# Altium schematic sheet Measurement_board.SchDoc(Measurement_board)
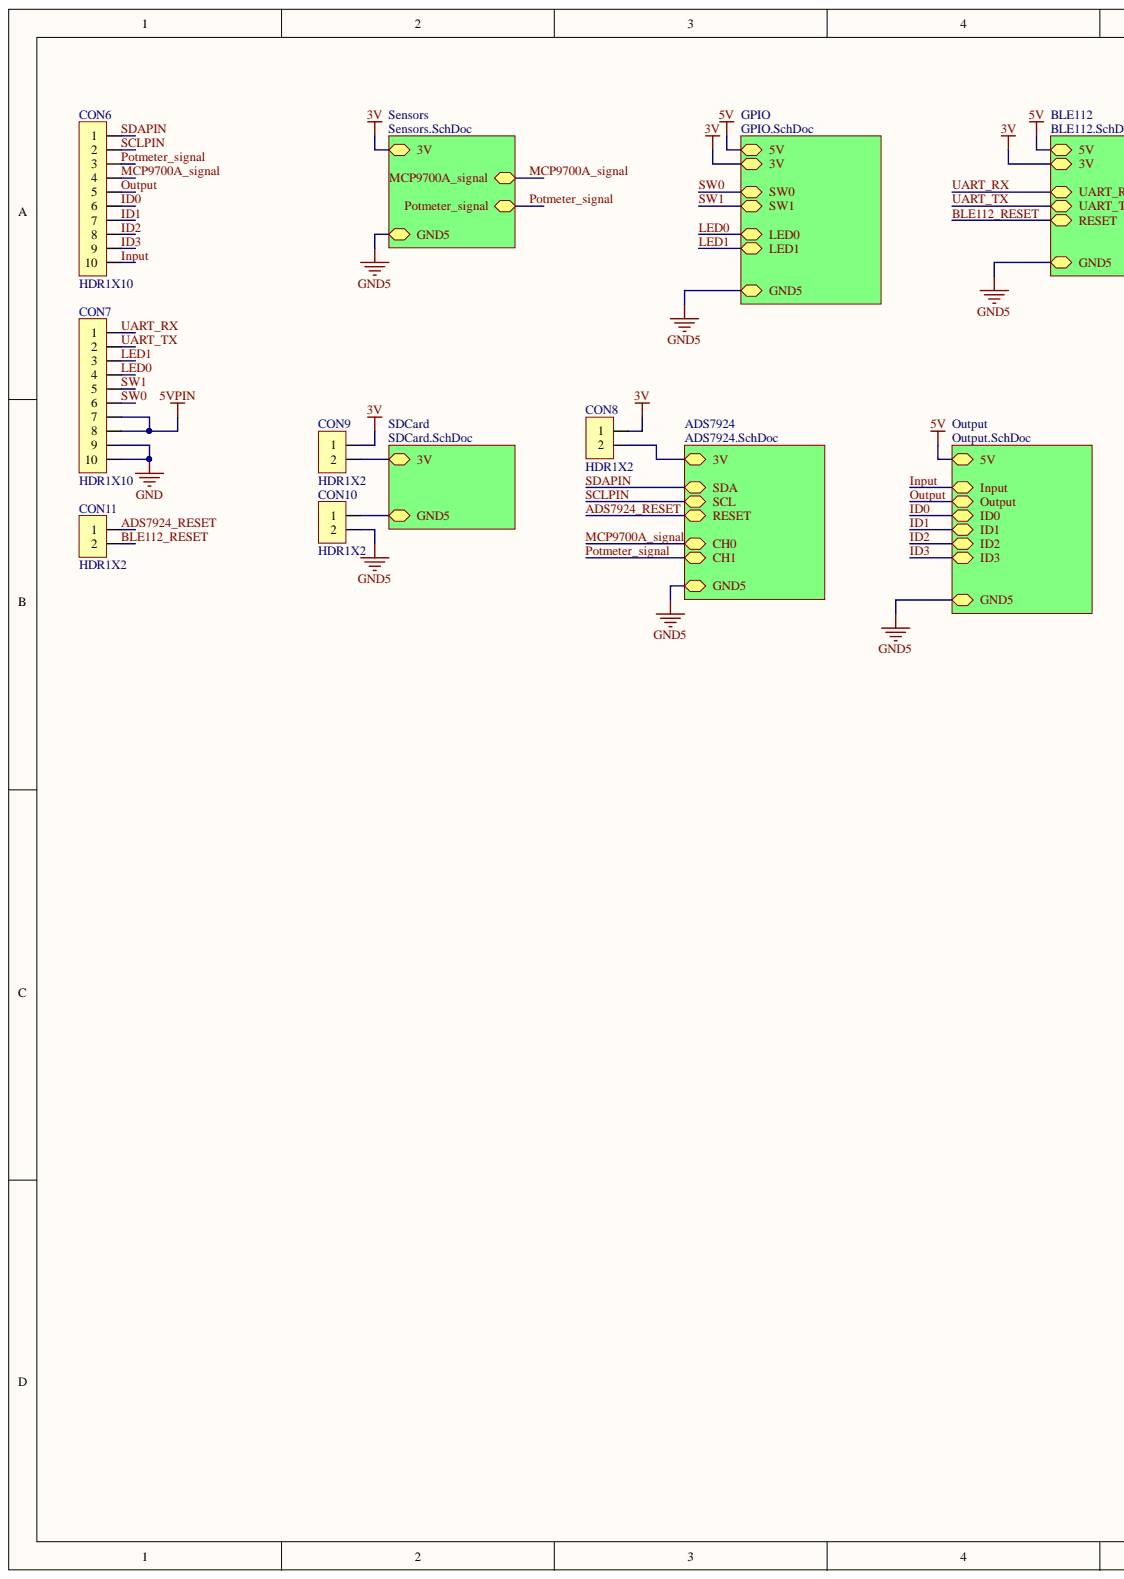
[diagram p0: Measurement_board.SchDoc, left]
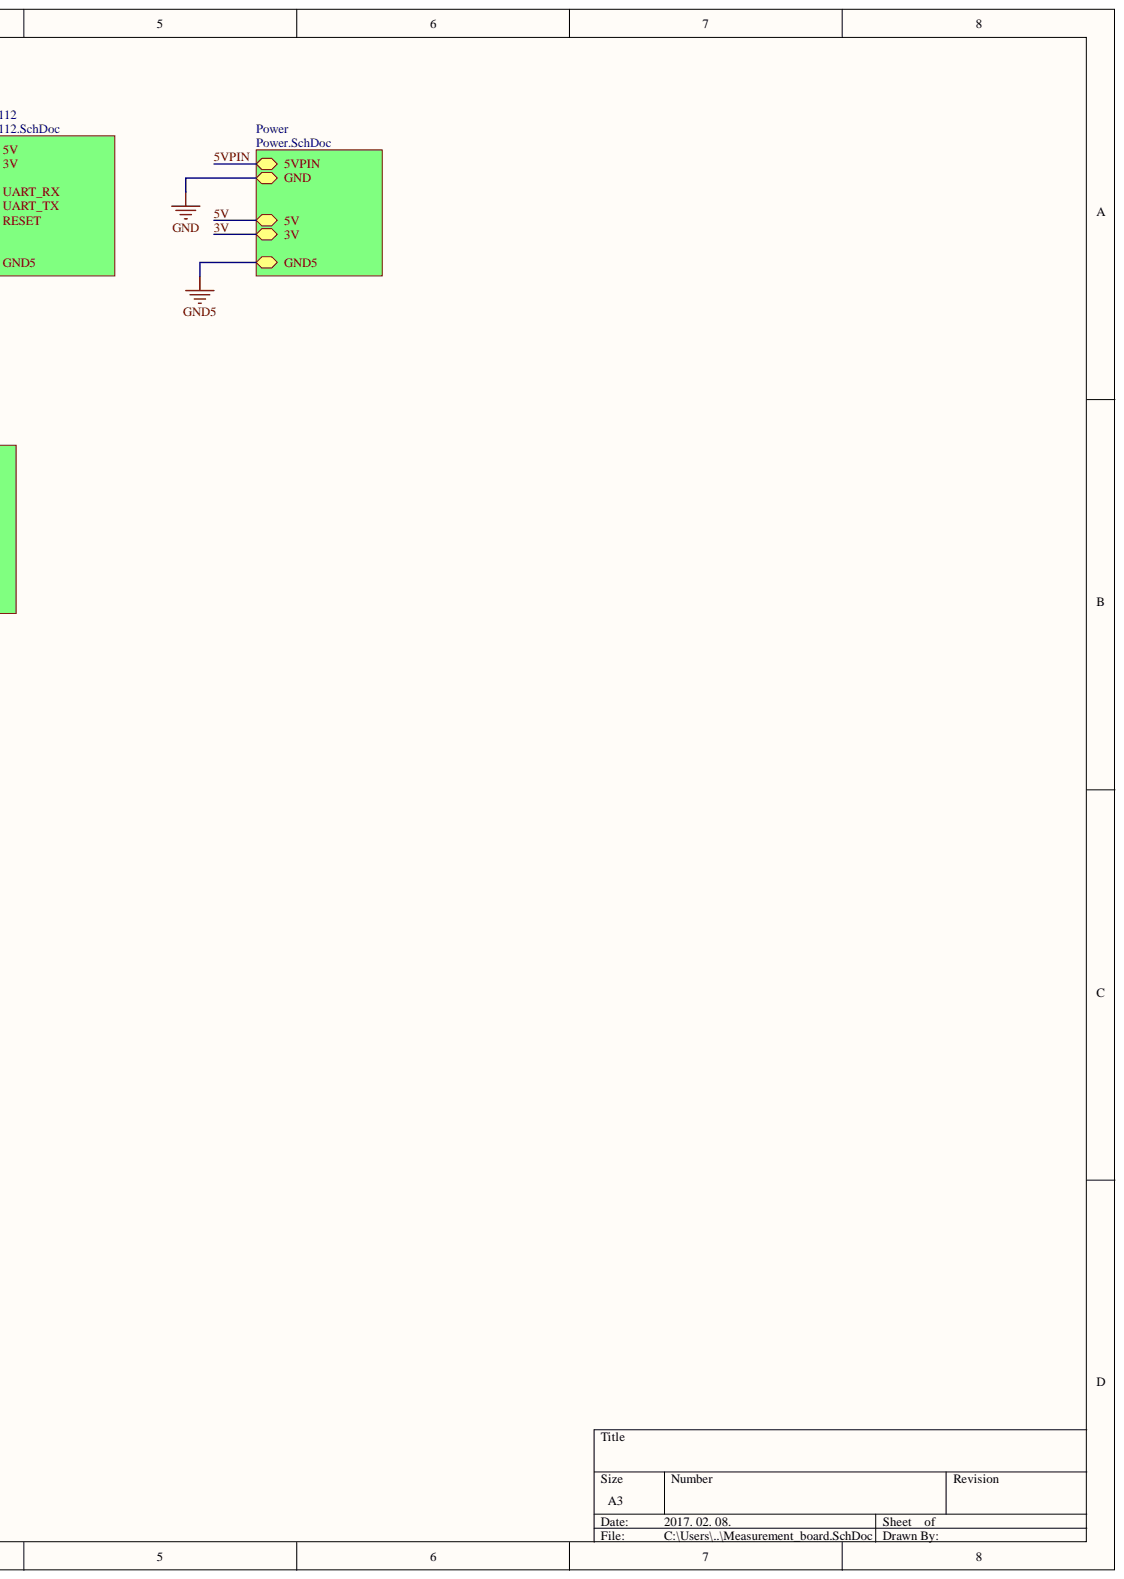
[diagram p1: Measurement_board.SchDoc, right]

## Parts
CON6  HDR1X10  [HDR1X10RAST]
CON7  HDR1X10  [HDR1X10RAST]
CON8  HDR1X2  [HDR1X2RAST]
CON9  HDR1X2  [HDR1X2RAST]
CON10  HDR1X2  [HDR1X2RAST]
CON11  HDR1X2  [HDR1X2RAST]

## Nets
GND: CON7.9 CON7.10
GND5: CON10.2
3V: CON8.1 CON9.1
5VPIN: CON7.7 CON7.8
ADS7924_RESET: CON11.1
BLE112_RESET: CON11.2
ID0: CON6.6
ID1: CON6.7
ID2: CON6.8
ID3: CON6.9
Input: CON6.10
LED0: CON7.4
LED1: CON7.3
MCP9700A_signal: CON6.4
Output: CON6.5
Potmeter_signal: CON6.3
SCLPIN: CON6.2
SDAPIN: CON6.1
SW0: CON7.6
SW1: CON7.5
UART_RX: CON7.1
UART_TX: CON7.2
NetCON8_2: CON8.2
NetCON9_2: CON9.2
NetCON10_1: CON10.1
5V:

## Library data of the parts
CON6 CON7 = HDR1X10: Component Kind: Standard; Library Name: diploma.SchLib
CON8-CON11 = HDR1X2: Component Kind: Standard; Library Name: diploma.SchLib
